# Altium schematic sheet RS232.SchDoc
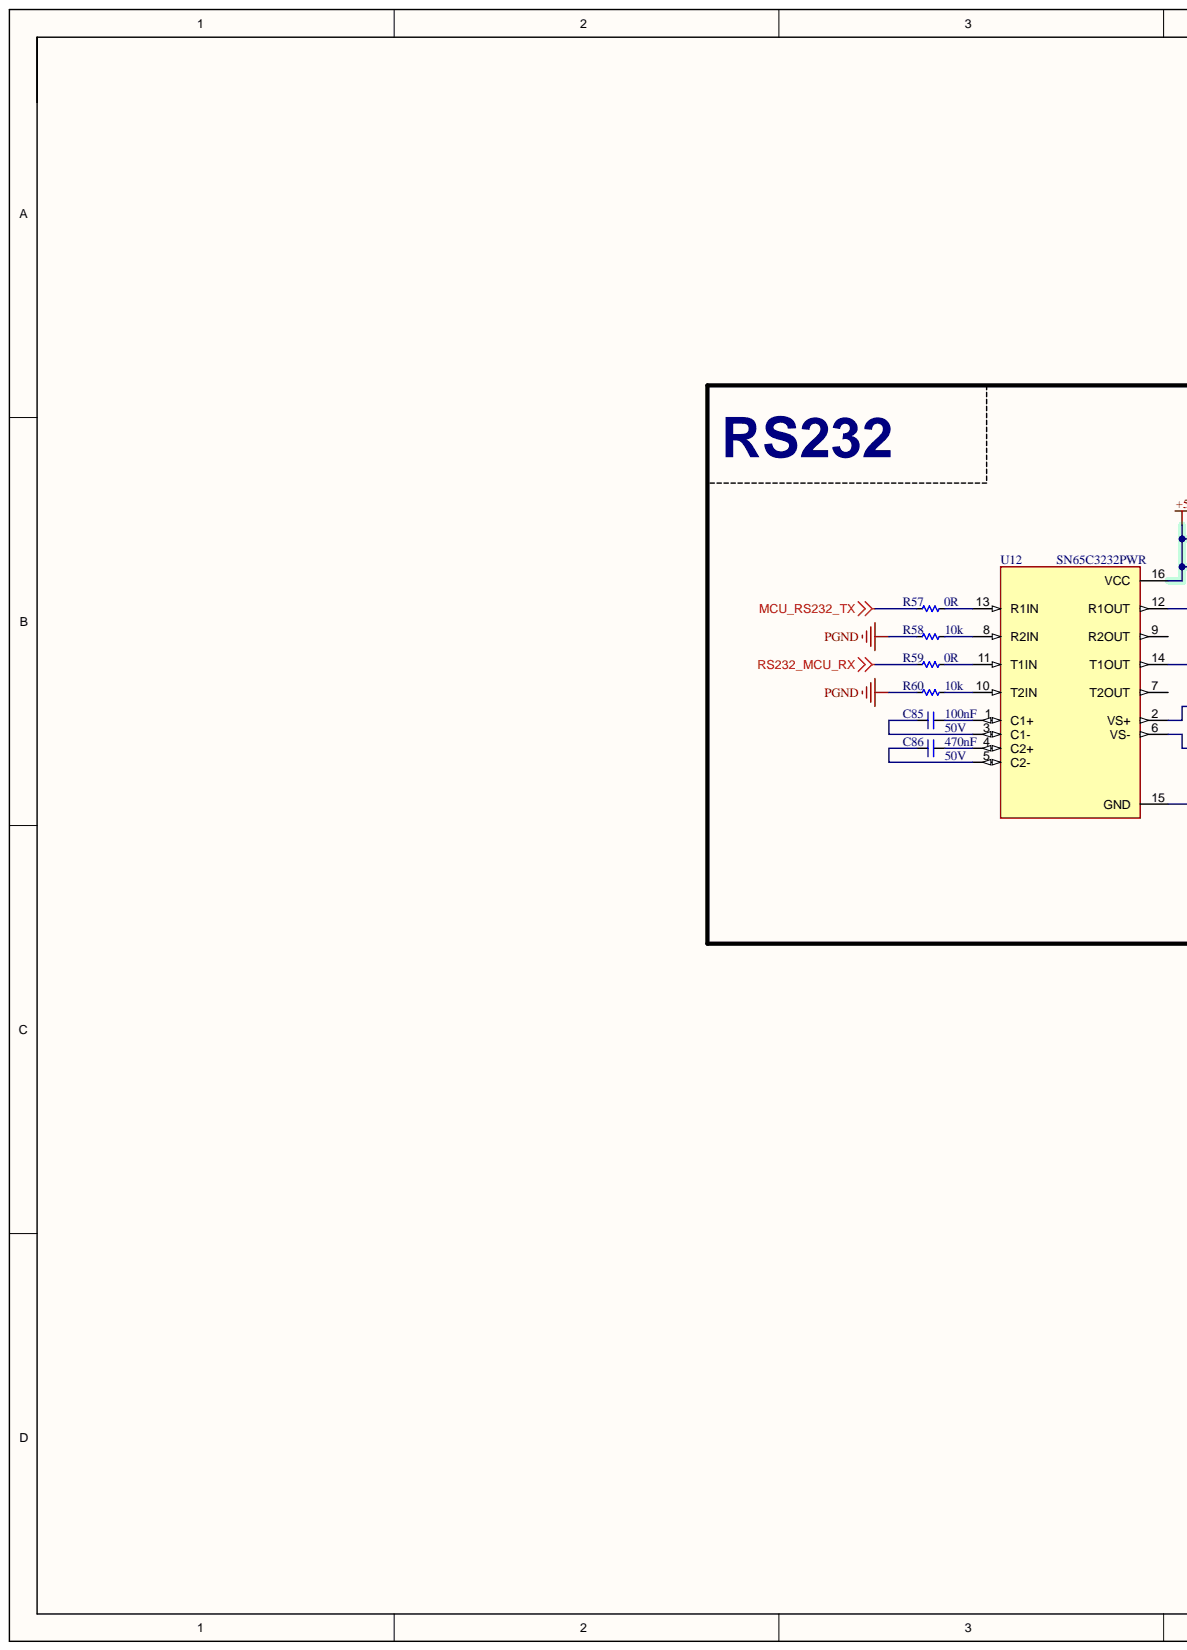
[diagram p0: RS232.SchDoc, left]
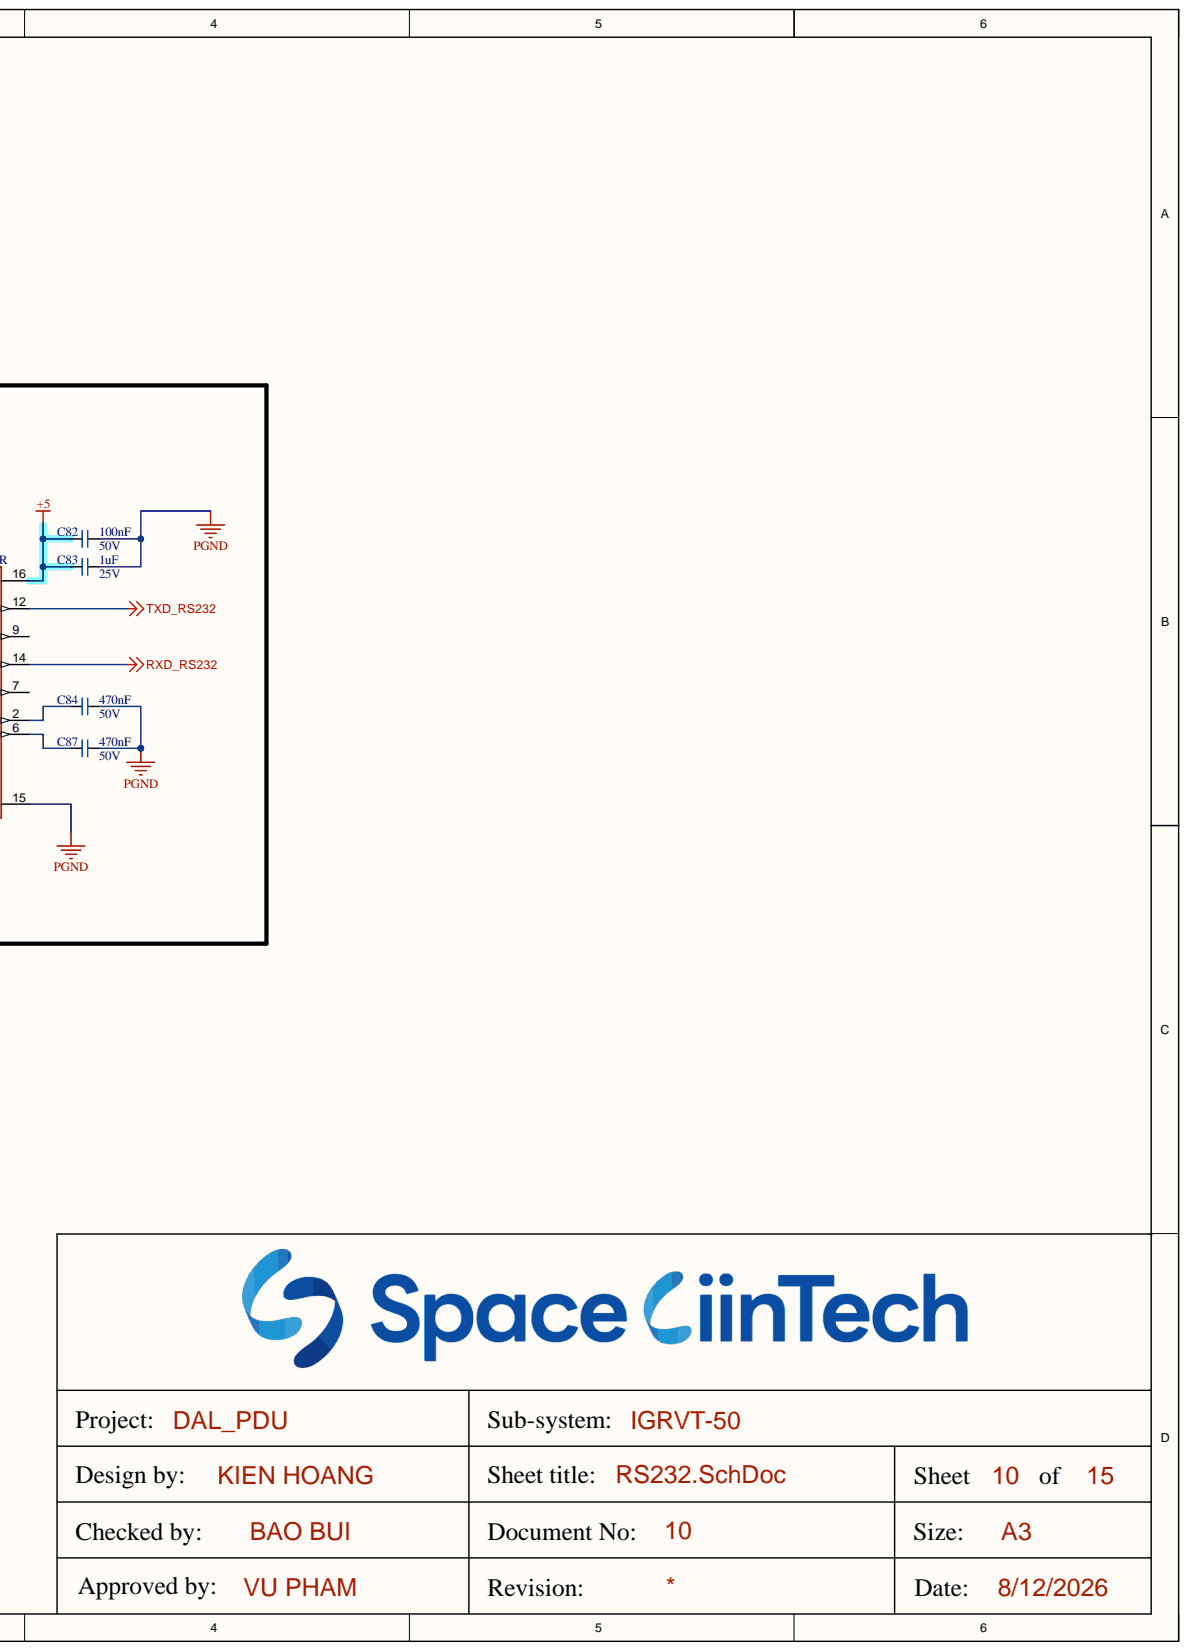
[diagram p1: RS232.SchDoc, right]

## Parts
C82  100nF  Voltage: 50V  [CAP_C_1608X80]
C83  1uF  Voltage: 25V  [CAP_C_1608X80]
C84  470nF  Voltage: 50V  [CAP_C_1608X80]
C85  100nF  Voltage: 50V  [CAP_C_1608X80]
C86  470nF  Voltage: 50V  [CAP_C_1608X80]
C87  470nF  Voltage: 50V  [CAP_C_1608X80]
R57  0R  [RES_C_1608X60]
R58  10k  [RES_C_1608X60]
R59  0R  [RES_C_1608X60]
R60  10k  [RES_C_1608X60]
U12  SN65C3232PWR  [TSSOP-16]

## Nets
+5: C82.2 C83.2 U12.16(VCC)
MCU_RS232_TX: R57.1
PGND: C82.1 C83.1 C84.1 C87.1 R58.1 R60.1 U12.15(GND)
RS232_MCU_RX: R59.1
RXD_RS232: U12.14(T1OUT)
TXD_RS232: U12.12(R1OUT)
NetC84_2: C84.2 U12.2(VS+)
NetC85_1: C85.1 U12.1(C1+)
NetC85_2: C85.2 U12.3(C1-)
NetC86_1: C86.1 U12.4(C2+)
NetC86_2: C86.2 U12.5(C2-)
NetC87_2: C87.2 U12.6(VS-)
NetR57_2: R57.2 U12.13(R1IN)
NetR58_2: R58.2 U12.8(R2IN)
NetR59_2: R59.2 U12.11(T1IN)
NetR60_2: R60.2 U12.10(T2IN)

Not connected: U12 7(T2OUT) 9(R2OUT)

## Library data of the parts
C82-C87 = _CAP_C_2: CAP; Component Kind: Standard; Library Name: LABLIB.SchLib
R57-R60 = _RES_B_2_2: RES; Component Kind: Standard; Library Name: LABLIB.SchLib
U12 = SN65C3232PWR: Component Kind: Standard; Library Name: KIENASIY123_LIB.IntLib
